# Altium schematic sheet Sheet2.SchDoc
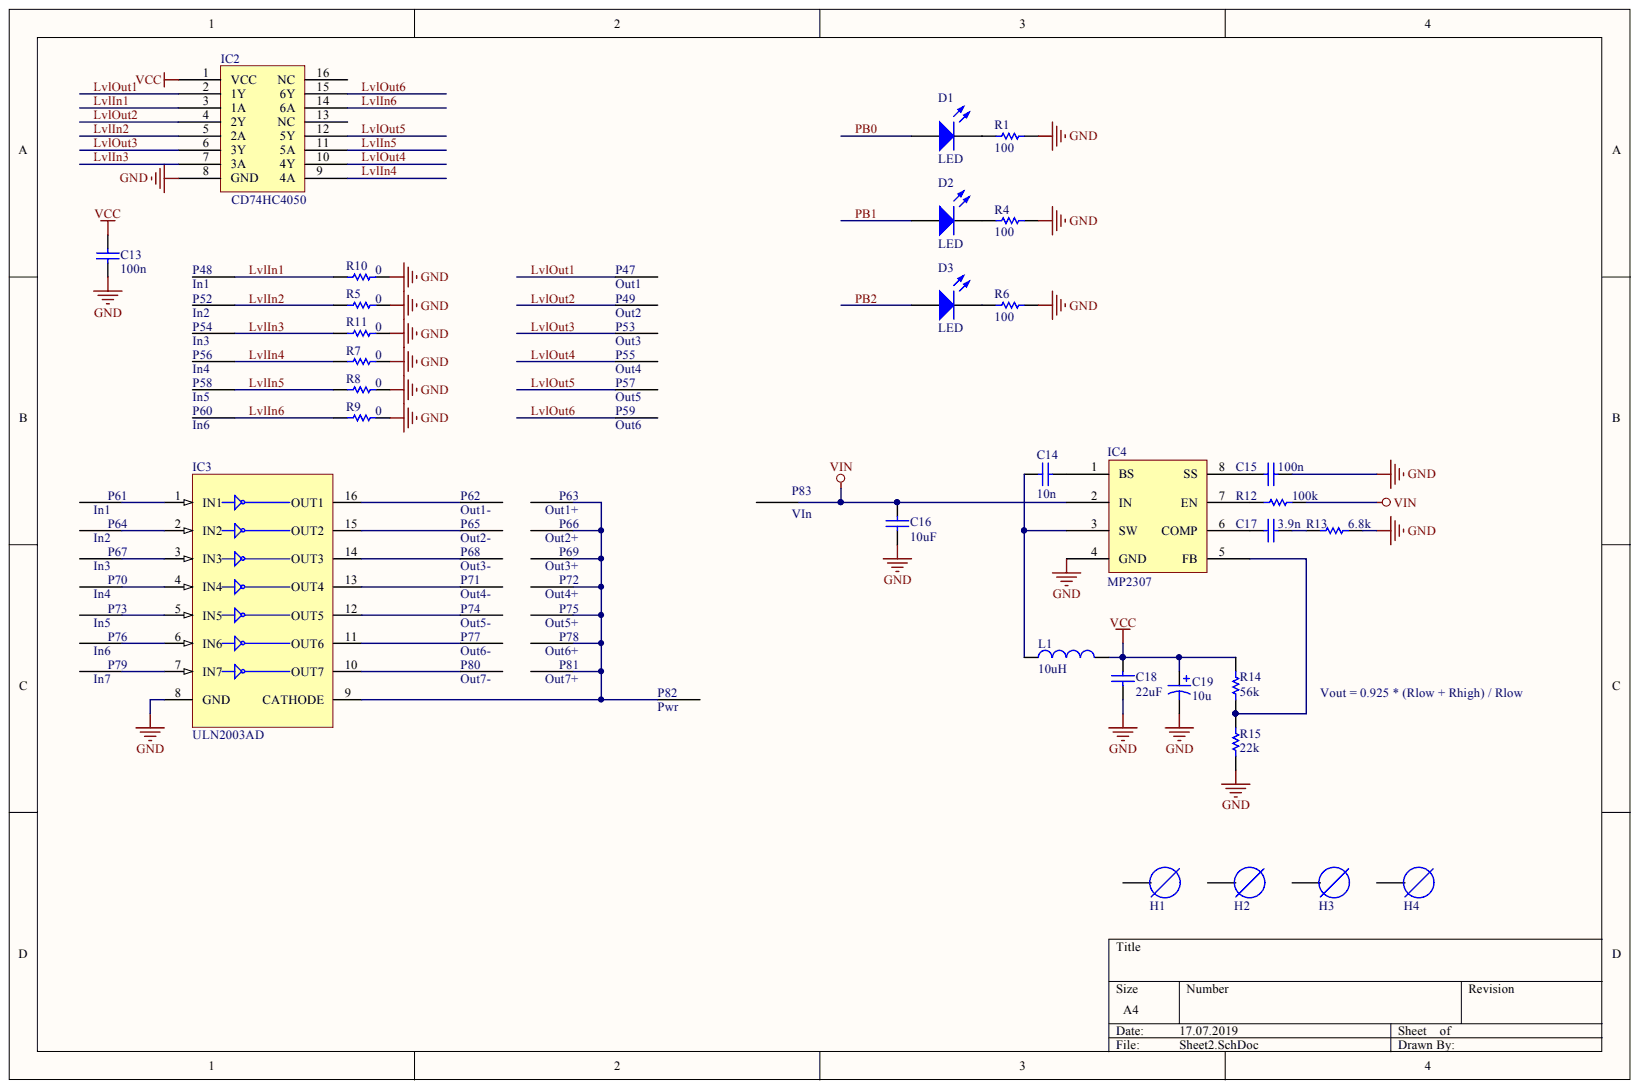
[diagram p0: Sheet2.SchDoc]

## Parts
C13  100n  [CAPC2013X88X50NL20T25]
C14  10n  [CAPC2013X88X50ML20T25]
C15  100n  [CAPC2013X88X50ML20T25]
C16  10uF  [CAPC3216X180X50ML20T25]
C17  3.9n  [CAPC2013X88X50ML20T25]
C18  22uF  [CAPC3216X180X50ML20T25]
C19  10u  [PCB-os9v8vfwvkqq3lbaacj2-1]
D1  LED  [PCB-9ifma0oh53wy532w7hco-1]
D2  LED  [PCB-9ifma0oh53wy532w7hco-1]
D3  LED  [PCB-9ifma0oh53wy532w7hco-1]
H1  Drill Hole  [DRILL-M3-TIGHT]  (value not drawn)
H2  Drill Hole  [DRILL-M3-TIGHT]  (value not drawn)
H3  Drill Hole  [DRILL-M3-TIGHT]  (value not drawn)
H4  Drill Hole  [DRILL-M3-TIGHT]  (value not drawn)
IC2  74HC4050  [PCB-45j9d39213syzapazsci-1]
IC3  ULN2003AD  [SOIC127P600X175-16N]
IC4  MP2307  [PCB-fb5q7dzrso3xip9pdmgx-1]
L1  10uH  [PCB-rmvze0u0mreqqt4ko16r-1]
P47  Out1  [PIN-089]
P48  In1  [PIN-089]
P49  Out2  [PIN-089]
P52  In2  [PIN-089]
P53  Out3  [PIN-089]
P54  In3  [PIN-089]
P55  Out4  [PIN-089]
P56  In4  [PIN-089]
P57  Out5  [PIN-089]
P58  In5  [PIN-089]
P59  Out6  [PIN-089]
P60  In6  [PIN-089]
P61  In1  [PIN-089]
P62  Out1-  [PIN-089]
P63  Out1+  [PIN-089]
P64  In2  [PIN-089]
P65  Out2-  [PIN-089]
P66  Out2+  [PIN-089]
P67  In3  [PIN-089]
P68  Out3-  [PIN-089]
P69  Out3+  [PIN-089]
P70  In4  [PIN-089]
P71  Out4-  [PIN-089]
P72  Out4+  [PIN-089]
P73  In5  [PIN-089]
P74  Out5-  [PIN-089]
P75  Out5+  [PIN-089]
P76  In6  [PIN-089]
P77  Out6-  [PIN-089]
P78  Out6+  [PIN-089]
P79  In7  [PIN-089]
P80  Out7-  [PIN-089]
P81  Out7+  [PIN-089]
P82  Pwr  [PIN-089]
P83  VIn  [PIN-089]
R1  100  [RESC2012X07N]
R4  100  [RESC2012X07N]
R5  0  [RESC2012X07N]
R6  100  [RESC2012X07N]
R7  0  [RESC2012X07N]
R8  0  [RESC2012X07N]
R9  0  [RESC2012X07N]
R10  0  [RESC2012X07N]
R11  0  [RESC2012X07N]
R12  100k  [RESC2013X70X40ML20T20]
R13  6.8k  [RESC2013X70X40ML20T20]
R14  56k  [RESC2013X70X40ML20T20]
R15  22k  [RESC2013X70X40ML20T20]

## Nets
GND: C13.2 C15.1 C16.1 C18.1 C19.2 IC2.8(GND) IC3.8(GND) IC4.4(GND) R1.1 R4.1 R5.1 R6.1 R7.1 R8.1 R9.1 R10.1 R11.1 R13.2 R15.2
VCC: C13.1 C18.2 C19.1 IC2.1(VCC) L1.2 R14.1
VIN: C16.2 IC4.2(IN) P83.1 R12.2
LVLIN1: IC2.3(1A) P48.1 R10.2
LVLIN2: IC2.5(2A) P52.1 R5.2
LVLIN3: IC2.7(3A) P54.1 R11.2
LVLIN4: IC2.9(4A) P56.1 R7.2
LVLIN5: IC2.11(5A) P58.1 R8.2
LVLIN6: IC2.14(6A) P60.1 R9.2
LVLOUT1: IC2.2(1Y) P47.1
LVLOUT2: IC2.4(2Y) P49.1
LVLOUT3: IC2.6(3Y) P53.1
LVLOUT4: IC2.10(4Y) P55.1
LVLOUT5: IC2.12(5Y) P57.1
LVLOUT6: IC2.15(6Y) P59.1
PB0: D1.1
PB1: D2.1
PB2: D3.1
NetC14_1: C14.1 IC4.1(BS)
NetC14_2: C14.2 IC4.3(SW) L1.1
NetC15_2: C15.2 IC4.8(SS)
NetC17_1: C17.1 R13.1
NetC17_2: C17.2 IC4.6(COMP)
NetD1_2: D1.2 R1.2
NetD2_2: D2.2 R4.2
NetD3_2: D3.2 R6.2
NetIC3_1: IC3.1(IN1) P61.1
NetIC3_2: IC3.2(IN2) P64.1
NetIC3_3: IC3.3(IN3) P67.1
NetIC3_4: IC3.4(IN4) P70.1
NetIC3_5: IC3.5(IN5) P73.1
NetIC3_6: IC3.6(IN6) P76.1
NetIC3_7: IC3.7(IN7) P79.1
NetIC3_9: IC3.9(CATHODE) P63.1 P66.1 P69.1 P72.1 P75.1 P78.1 P81.1 P82.1
NetIC3_10: IC3.10(OUT7) P80.1
NetIC3_11: IC3.11(OUT6) P77.1
NetIC3_12: IC3.12(OUT5) P74.1
NetIC3_13: IC3.13(OUT4) P71.1
NetIC3_14: IC3.14(OUT3) P68.1
NetIC3_15: IC3.15(OUT2) P65.1
NetIC3_16: IC3.16(OUT1) P62.1
NetIC4_5: IC4.5(FB) R14.2 R15.1
NetIC4_7: IC4.7(EN) R12.1

Not connected: H1 1; H2 1; H3 1; H4 1; IC2 13(NC) 16(NC)

## Library data of the parts
C13 = CMP-3913301-2: CAPACITOR, 0805, C0G, 50V, 2.2NF; Capacitance: 2.2e-09 F; Capacitance Tolerance: ±5%; Dielectric Characteristic: C0G/NP0; Dielectric Material: Ceramic; Lead-Free Status: Lead Free; Voltage Rating (DC): 50.0 V; Case/Package: 0805; Component Kind: Standard; Library Name: CommunityVault; Mounting Style: Surface Mount; OctopartID: bb4973a362c9648e; Octopart Url: https://octopart.com/c0805c222j5gacauto-kemet-31522022; Packaging: Cut Tape (CT); REACH SVHC Compliance: No SVHC; RoHS: Compliant
C14 C15 C17 = C0805C104J3RACTU: Multilayer Ceramic Capacitors MLCC - SMD/SMT 25volts 0.1uF X7R 5%; Capacitance: 1e-07 F; Lead-Free Status: R; Voltage Rating (DC): 25.0 V; Capacitance Tolerance: ±5%; Case/Package: 0805; Component Kind: Standard; Dielectric Characteristic: X7R; Dielectric Material: Ceramic; Library Name: Octopart library; Mounting Style: Surface Mount; OctopartID: 154cdccdb346ca8b; Octopart Url: https://octopart.com/c0805c104j3ractu-kemet-9143148; Packaging: Cut Tape (CT); RoHS: Compliant
C16 C18 = CMP-13177474-1: Manufacturer Part Number: C1206C106K9RACTU; CiivaID: 13177474; Ciiva URL: https://ciiva.com/part/c1206c106k9ractu-13177474; Component Kind: Standard; Library Name: CommunityVault
C19 = UPW1H100MDD: PW Series 10 uF 50 V 105°C Ø5 x 11 mm LS=2 mm Radial Aluminum Electrolytic Cap; Capacitance Tolerance: ±20%; Lead-Free Status: Lead Free; Component Kind: Standard; Library Name: Octopart library; Size-Height: 11.0 mm
D1-D3 = 598-8110-107F: 598-8110-107F, Dialight, SMD LED 0805 Package, Red Single color SOLD IN INCREMENTS OF 4000. (REEL); Case/Package (SI): 2012; Forward Voltage: 2.20 V; Lead-Free Status: Lead Free; Lens Type: Non-Diffused; Luminous Intensity: 40.0 mcd; Viewing Angle: 140 °; Wavelength: 635 nm, 642 nm; Case/Package: 0805; Color: Red; Component Kind: Standard; Lens Color: Red; Library Name: Octopart library; Mounting Style: Surface Mount, Vertical; Number of Pins: 2; OctopartID: bcc375c4d97c6304; Octopart URL: https://octopart.com/598-8110-107f-dialight-868569; Operating Temperature: 85.0 °C (max); REACH SVHC Compliance: No SVHC; RoHS: Compliant; Size-Height: 700 µm; Size-Length: 2.00 mm; Size-Width: 1.25 mm
H1-H4 = Drill Hole-4: Drill hole with varying diameters; CustomComponentID: 6fg2s7uxucbqgec4pqmd; Component Kind: Standard; Library Name: FavoritesLibrary
IC2 = CMP-24a7edad7031fb60-2: High Speed CMOS Logic Hex Non-Inverting Buffers 16-SOIC -55 to 125; Lead-Free Status: Lead Free; Propagation Delay Max (tpd): 21.0 ns; Quiescent Current: 2.00 µA (max); Supply Voltage (DC): 2.00 V to 6.00 V; Component Kind: Standard; Library Name: CommunityVault; Mounting Style: Surface Mount; Number of Pins: 16; Packaging: Tube, Rail
IC3 = CMP-aa40634b02c87587-2: Ti# Uln2003ad Transistor Array; Npn; 30 v; -2.5 a; 1.7 v; 0.93 Ma (Typ.); 1 5 Pf (Typ.); 0.25; Current Rating: 0.5 A; Drivers Per Package: 7; Lead-Free Status: Lead Free; Voltage Rating (DC): 50.0 V; Case/Package: SOIC, PDIP; Component Kind: Standard; Library Name: CommunityVault; Lifecycle Status: Active; Manufacturer Part Number: ULN2003AD; Mounting Style: Surface Mount; Number of Pins: 16; OctopartID: aa40634b02c87587; Octopart URL: http://octopart.com/uln2003ad-texas+instruments-101870; Output Current: 0.5 A; Packaging: Tube; Polarity: NPN; REACH SVHC Compliance: No SVHC; RoHS: Compliant
IC4 = CMP-a0umfuhc7sltls88zj5l-3: MP2307 3A 23V 340kHz Synchronous Rectified Step-Down Converter; Component Kind: Standard; Library Name: CommunityVault
L1 = CMP-55299c215d978aee-1: Inductor Power Wirewound 10uH 20% 1KHz 25Q-Factor Ferrite 2.3A 70mOhm DCR T/R; Manufacturer Part Number: SDR0805-100ML; Inductance: 10.0 µH; Self Resonant Frequency: 22.0 MHz; Case/Package: 0805; Component Kind: Standard; Library Name: CommunityVault; Number of Pins: 2; Octopart URL: http://octopart.com/sdr0805-100ml-bourns-142808; Packaging: Cut Tape (CT); REACH SVHC Compliance: No SVHC
P47-P49 P52-P83 = PIN-7: One-pin connector; CustomComponentID: iv9w4hav5xulhqaz2xo1; Component Kind: Standard; Library Name: FavoritesLibrary
R1 R4-R11 = CMP-1041dbfef0d0cf86-1: Res, Thin Film, 7k87, 0.1%, 0.25w, 0805; Manufacturer Part Number: RP73PF2A7K87BTDF; Power Rating: 250 mW; Resistance: 7.87 kΩ; Voltage Rating (DC): 150 V; Case/Package: 0805, 2012; Component Kind: Standard; Library Name: CommunityVault; Lifecycle Status: Not Listed by Manufacturer; Mounting Style: Surface Mount; OctopartID: 1041dbfef0d0cf86; Octopart URL: http://octopart.com/rp73pf2a7k87btdf-te+connectivity-45467127; Packaging: Cut Tape (CT); REACH SVHC Compliance: No SVHC; Resistance Tolerance: ±0.1%; RoHS: Compliant
R12-R15 = ERJ-6GEYJ164V: Thick Film Resistors - SMD 0805 160Kohms 5% Tol; Case/Package (SI): 2012; Lead-Free Status: Lead Free; Power Rating: 0.125 W; Resistance: 160000.0 Ω; Voltage Rating (DC): 150.0 V; Case/Package: 0805; Component Kind: Standard; Library Name: Octopart library; Lifecycle Status: Not Listed by Manufacturer; Mounting Style: Surface Mount; OctopartID: 56869cc1dc318941; Octopart Url: https://octopart.com/erj-6geyj164v-panasonic-55422929; Packaging: Cut Tape (CT); REACH SVHC Compliance: No SVHC; Resistance Tolerance: ±5%; RoHS: Compliant; Size-Height: 0.0006 m; Size-Length: 0.002 m; Size-Width: 0.00125 m
